# Altium schematic sheet radio.SchDoc(radio)
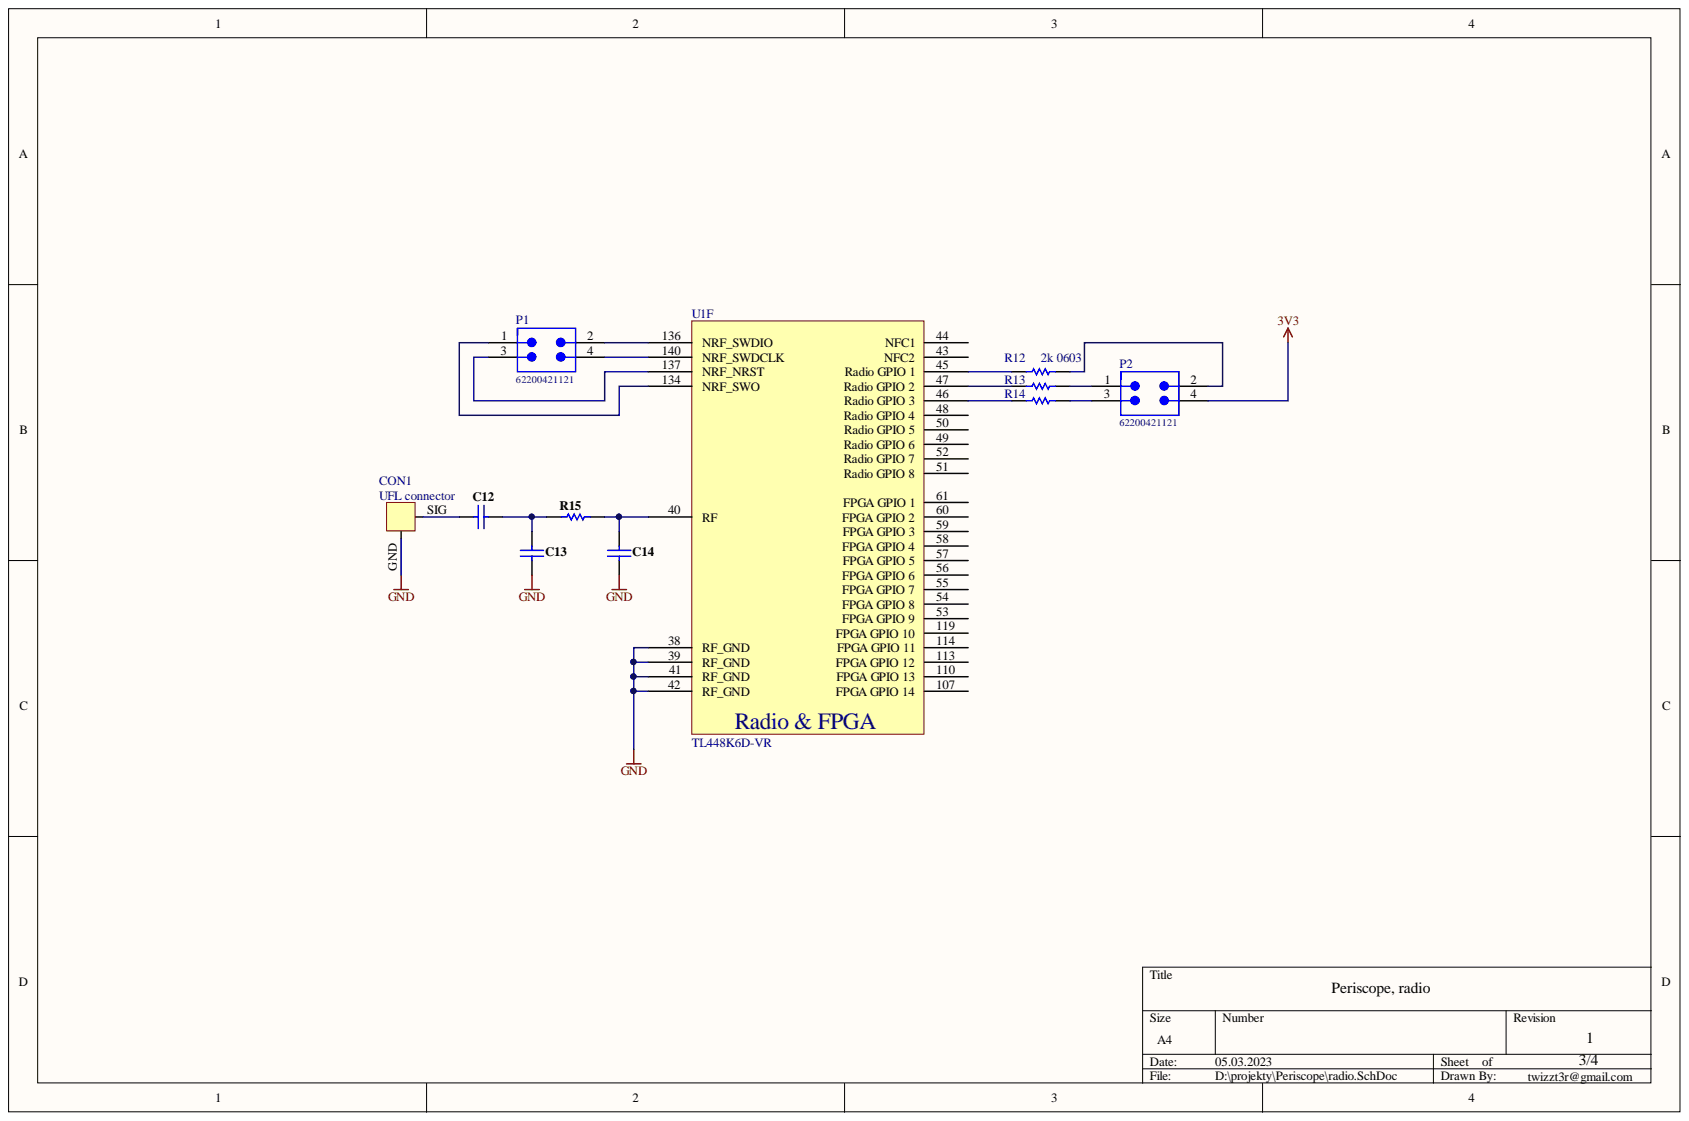
[diagram p0: radio.SchDoc]

## Parts
C12  0R 0402  [WCAP-CSGP_0402_H=0.5mm]  (value not drawn)
C13  DNP  [WCAP-CSGP_0402_H=0.5mm]  (value not drawn)
C14  DNP  [WCAP-CSGP_0402_H=0.5mm]  (value not drawn)
CON1  UFL connector  [uFL SMT]
P1  62200421121  [62200421121]
P2  62200421121  [62200421121]
R12  2k 0603  [R_0603]
R13  2k 0603  [R_0603]
R14  2k 0603  [R_0603]  (value not drawn)
R15  0R 0402  [R_0402]  (value not drawn)
U1  TL448K6D-VR  [TL448K6D-VR]  (part F)

## Nets
3V3: P2.4
GND: C13.1 C14.1 CON1.GND U1.38(RF_GND) U1.39(RF_GND) U1.41(RF_GND) U1.42(RF_GND)
NetC12_1: C12.1 C13.2 R15.1
NetC12_2: C12.2 CON1.SIG
NetC14_2: C14.2 R15.2 U1.40(RF)
NetP1_1: P1.1 U1.134(NRF_SWO)
NetP1_2: P1.2 U1.136(NRF_SWDIO)
NetP1_3: P1.3 U1.137(NRF_NRST)
NetP1_4: P1.4 U1.140(NRF_SWDCLK)
NetP2_1: P2.1 R13.2
NetP2_2: P2.2 R12.2
NetP2_3: P2.3 R14.2
NetR12_1: R12.1 U1.45(Radio_GPIO_1)
NetR13_1: R13.1 U1.47(Radio_GPIO_2)
NetR14_1: R14.1 U1.46(Radio_GPIO_3)

Not connected: U1 43(NFC2) 44(NFC1) 48(Radio_GPIO_4) 49(Radio_GPIO_6) 50(Radio_GPIO_5) 51(Radio_GPIO_8) 52(Radio_GPIO_7) 53(FPGA_GPIO_9) 54(FPGA_GPIO_8) 55(FPGA_GPIO_7) 56(FPGA_GPIO_6) 57(FPGA_GPIO_5) 58(FPGA_GPIO_4) 59(FPGA_GPIO_3) 60(FPGA_GPIO_2) 61(FPGA_GPIO_1) 107(FPGA_GPIO_14) 110(FPGA_GPIO_13) 113(FPGA_GPIO_12) 114(FPGA_GPIO_11) 119(FPGA_GPIO_10)

## Library data of the parts
C12-C14 = C_0402: C; Library Name: SCH.SchLib; Component Kind: Standard
CON1 = UFL: Library Name: SCH.SchLib; Component Kind: Standard
P1 P2 = 62200421121: WR-PHD Pin Header, THT, pitch 1.27mm, Dual Row, Vertical, 4p; Manufacturer: Wurth Elektronik; Working Voltage: 100VAC; Withstanding Voltage: 500VAC; Rated Current: 1A; Pin Number: 4; Contact Resistance: 20mOhm; Row Number: Dual; Published Date: May 2020; Pitch: 1.27mm; Packaging: Box; Orientation: Vertical; Operating Temperature: -40 to +105degC; Mounting Technology: THT; Manufacturer Part Number: 62200421121; Library Name: SCH.SchLib; Gender: Pin Header; ComponentLink2URL: https://www.we-online.com/catalog/datasheet/62200421121.pdf; ComponentLink2Description: Datasheet; ComponentLink1URL: http://www.we-online.de; ComponentLink1Description: Manufacturer; Component Kind: Standard
R12-R14 = R_0603: Library Name: SCH.SchLib; Component Kind: Standard
R15 = R_0402: 0402 (1005 Metric)  SMD; Tolerance: 5 %; Power: 0.063 W; Case-Metric: 1005; Case-EIA: 0402; Technology: SMT; Library Name: SCH.SchLib; Component Kind: Standard
U1 = TL448K6D-VR: Library Name: SCH.SchLib; Component Kind: Standard
